# Altium schematic sheet BlazeBus_1.SchDoc
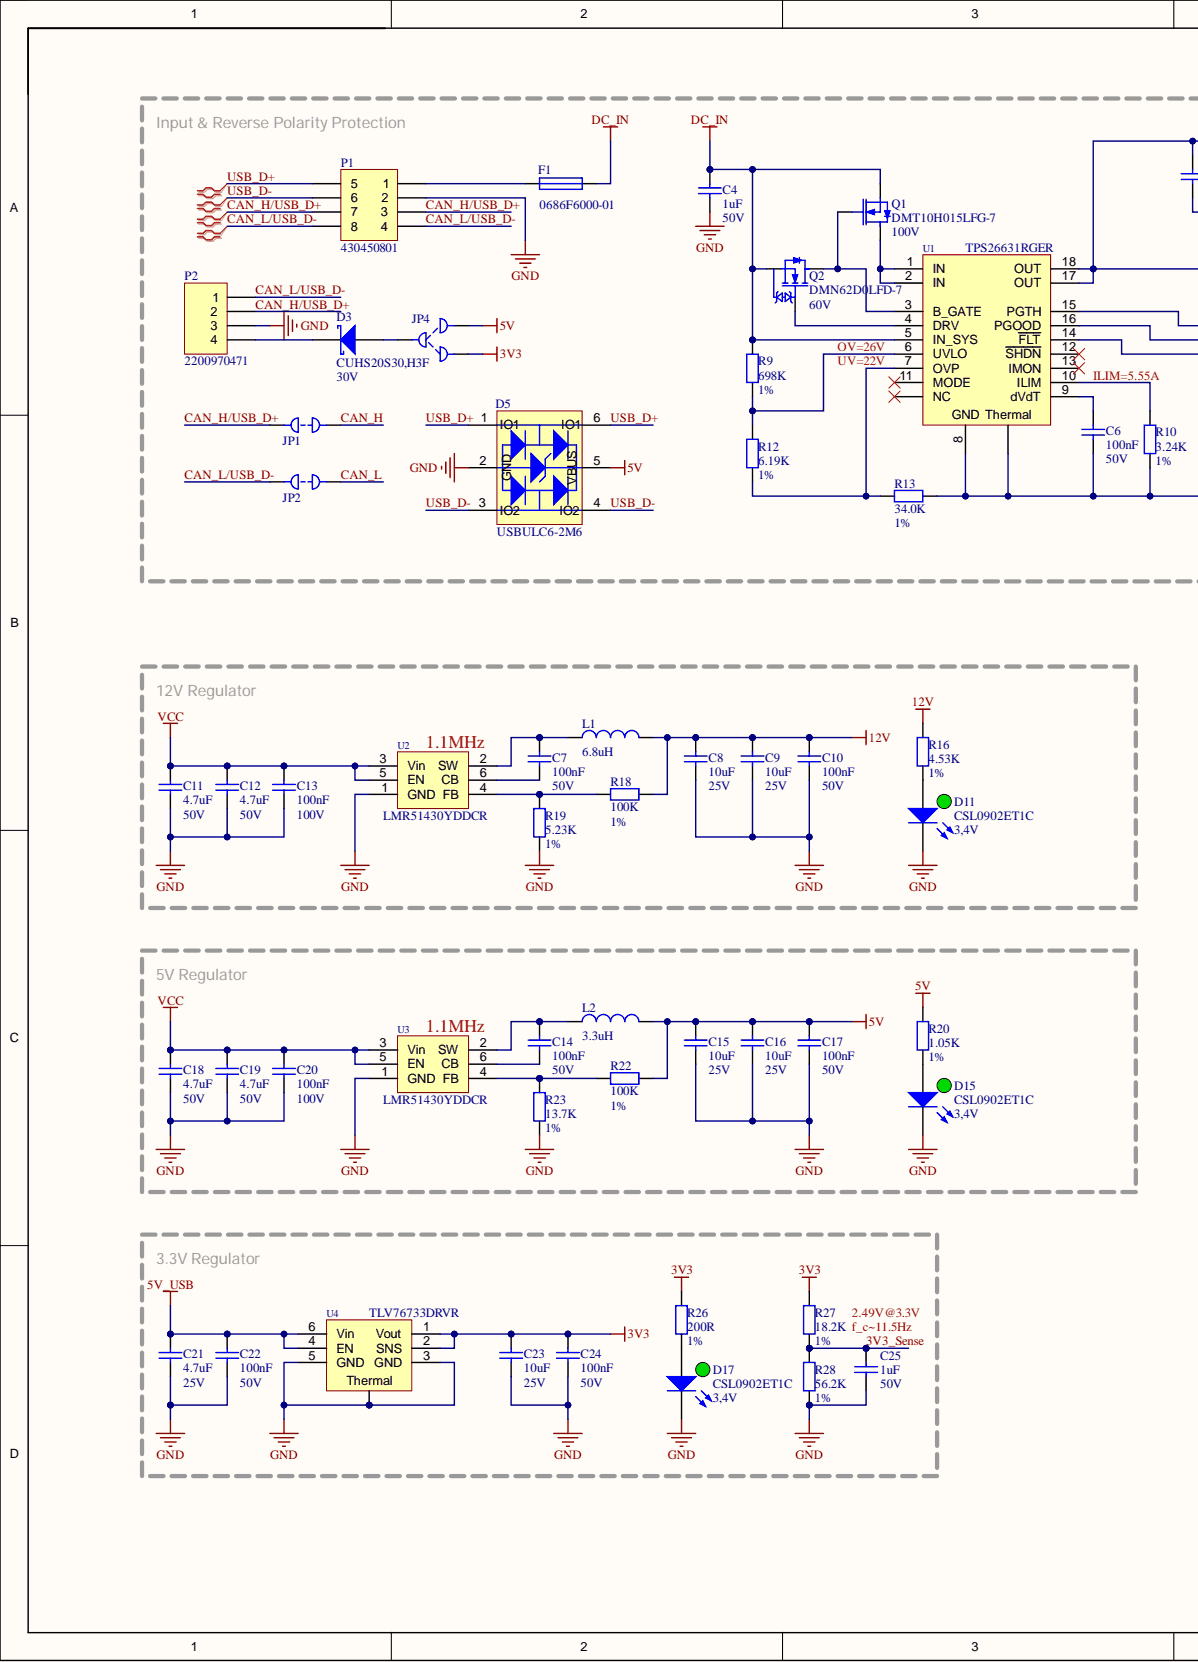
[diagram p0: BlazeBus_1.SchDoc, left]
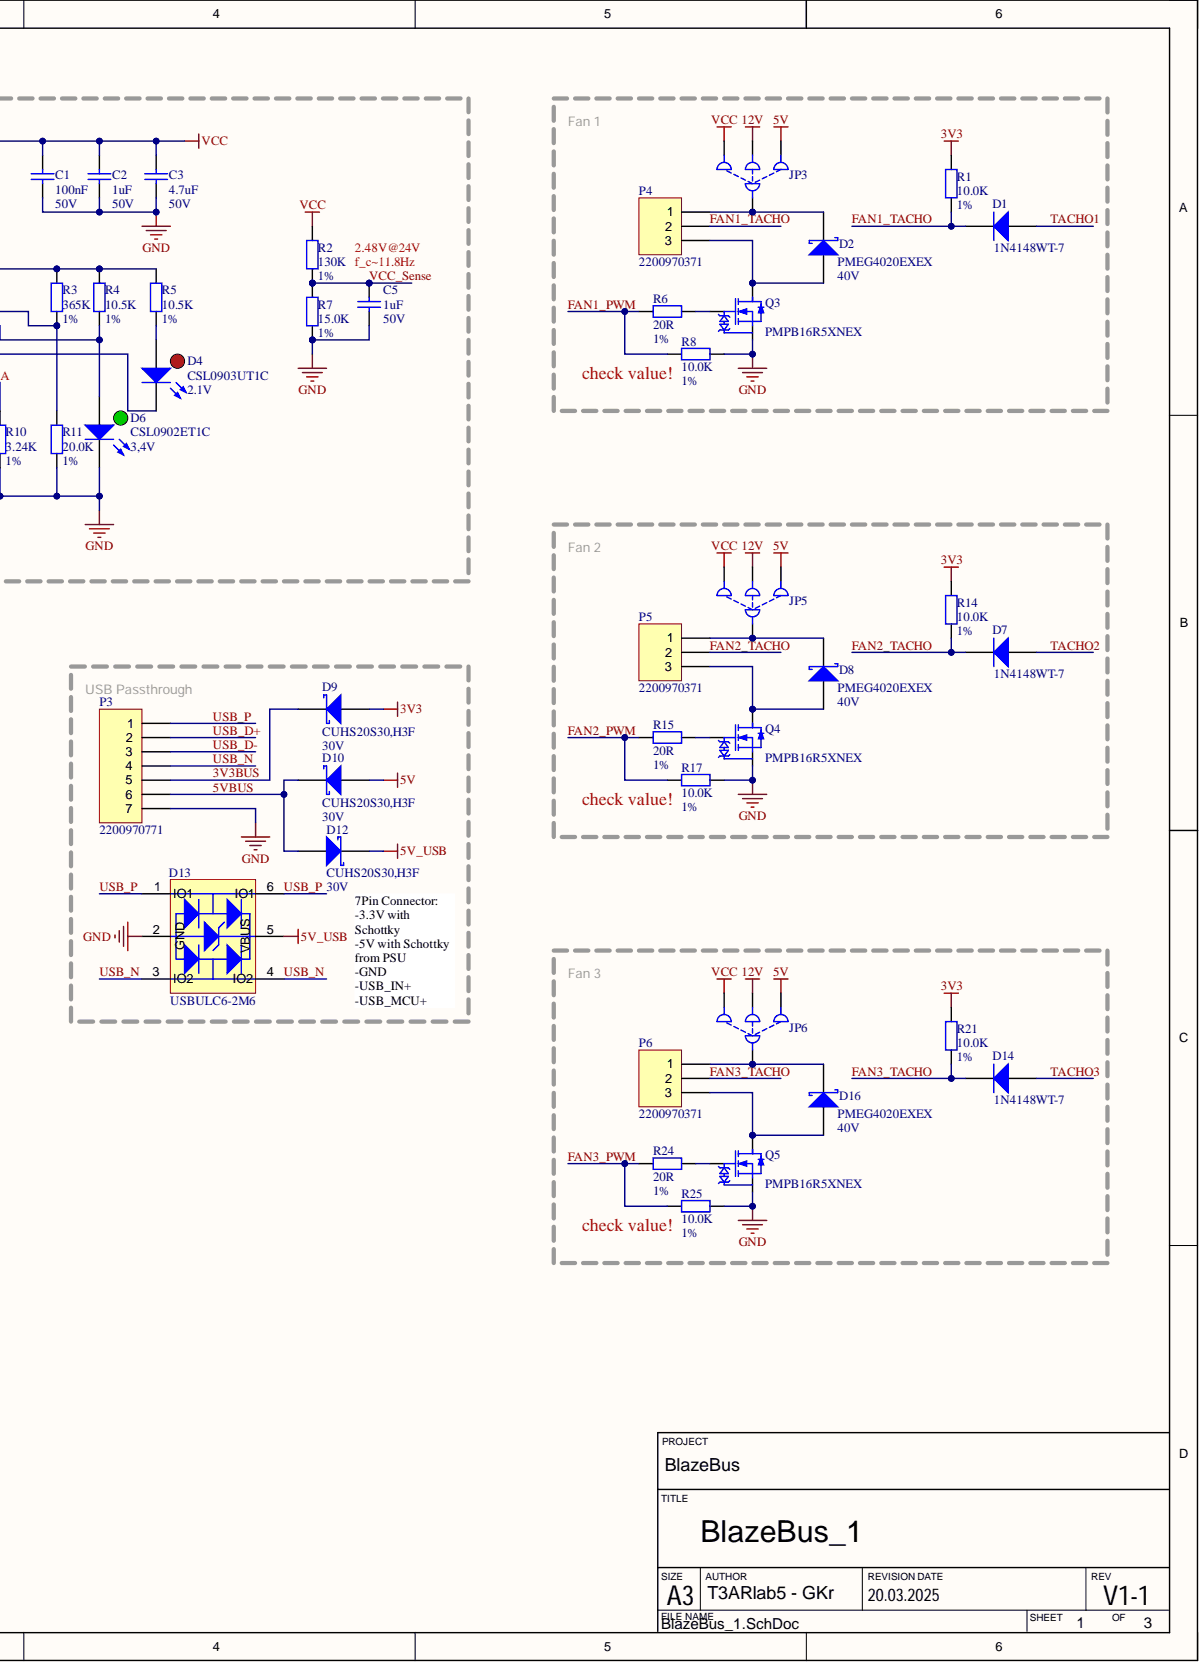
[diagram p1: BlazeBus_1.SchDoc, right]

## Parts
C1  C  value 100nF  Voltage Rating: 50V  [C_0402]
C2  C  value 1uF  Voltage Rating: 50V  [C_0603]
C3  C  value 4.7uF  Voltage Rating: 50V  [C_1206]
C4  C  value 1uF  Voltage Rating: 50V  [C_0805]
C5  C  value 1uF  Voltage Rating: 50V  [C_0603]
C6  C  value 100nF  Voltage Rating: 50V  [C_0402]
C7  C  value 100nF  Voltage Rating: 50V  [C_0402]
C8  C  value 10uF  Voltage Rating: 25V  [C_1206]
C9  C  value 10uF  Voltage Rating: 25V  [C_1206]
C10  C  value 100nF  Voltage Rating: 50V  [C_0402]
C11  C  value 4.7uF  Voltage Rating: 50V  [C_1206]
C12  C  value 4.7uF  Voltage Rating: 50V  [C_1206]
C13  C  value 100nF  Voltage Rating: 100V  [C_0603]
C14  C  value 100nF  Voltage Rating: 50V  [C_0402]
C15  C  value 10uF  Voltage Rating: 25V  [C_1206]
C16  C  value 10uF  Voltage Rating: 25V  [C_1206]
C17  C  value 100nF  Voltage Rating: 50V  [C_0402]
C18  C  value 4.7uF  Voltage Rating: 50V  [C_1206]
C19  C  value 4.7uF  Voltage Rating: 50V  [C_1206]
C20  C  value 100nF  Voltage Rating: 100V  [C_0603]
C21  C  value 4.7uF  Voltage Rating: 25V  [C_0805]
C22  C  value 100nF  Voltage Rating: 50V  [C_0402]
C23  C  value 10uF  Voltage Rating: 25V  [C_1206]
C24  C  value 100nF  Voltage Rating: 50V  [C_0402]
C25  C  value 1uF  Voltage Rating: 50V  [C_0603]
D1  Manufacturer ID: 1N4148WT-7  [SOD523]  (hidden comment: D_A2K1)
D2  Manufacturer ID: PMEG4020EXEX  Voltage Rating: 40V  [SOD123HP]  (hidden comment: D_Schottky_A2K1)
D3  Manufacturer ID: CUHS20S30,H3F  Voltage Rating: 30V  [D_2-SMD]  (hidden comment: D_Schottky_A2K1)
D4  Manufacturer ID: CSL0903UT1C  Voltage Rating: 2.1V  [LED_0603_red_Rohm]  (hidden comment: LED_red)
D5  Manufacturer ID: USBULC6-2M6  [6-UFDFN]  (hidden comment: D_TVS_USB)
D6  Manufacturer ID: CSL0902ET1C  Voltage Rating: 3,4V  [LED_0603_green_Rohm]  (hidden comment: LED_green)
D7  Manufacturer ID: 1N4148WT-7  [SOD523]  (hidden comment: D_A2K1)
D8  Manufacturer ID: PMEG4020EXEX  Voltage Rating: 40V  [SOD123HP]  (hidden comment: D_Schottky_A2K1)
D9  Manufacturer ID: CUHS20S30,H3F  [D_2-SMD]  (hidden comment: D_Schottky_A2K1)
D10  Manufacturer ID: CUHS20S30,H3F  Voltage Rating: 30V  [D_2-SMD]  (hidden comment: D_Schottky_A2K1)
D11  Manufacturer ID: CSL0902ET1C  Voltage Rating: 3,4V  [LED_0603_green_Rohm]  (hidden comment: LED_green)
D12  Manufacturer ID: CUHS20S30,H3F  Voltage Rating: 30V  [D_2-SMD]  (hidden comment: D_Schottky_A2K1)
D13  Manufacturer ID: USBULC6-2M6  [6-UFDFN]  (hidden comment: D_TVS_USB)
D14  Manufacturer ID: 1N4148WT-7  [SOD523]  (hidden comment: D_A2K1)
D15  Manufacturer ID: CSL0902ET1C  Voltage Rating: 3,4V  [LED_0603_green_Rohm]  (hidden comment: LED_green)
D16  Manufacturer ID: PMEG4020EXEX  Voltage Rating: 40V  [SOD123HP]  (hidden comment: D_Schottky_A2K1)
D17  Manufacturer ID: CSL0902ET1C  Voltage Rating: 3,4V  [LED_0603_green_Rohm]  (hidden comment: LED_green)
F1  Manufacturer ID: 0686F6000-01  [F_0603]  (hidden comment: Fuse)
JP1  Jumper  [R_0402 Jumper]  (value not drawn)
JP2  Jumper  [R_0402 Jumper]  (value not drawn)
JP3  Jumper 3-Way  [R_0402 Jumper 3-Way]  (value not drawn)
JP4  Jumper 2-Way  [R_0402 Jumper 2-Way]  (value not drawn)
JP5  Jumper 3-Way  [R_0402 Jumper 3-Way]  (value not drawn)
JP6  Jumper 3-Way  [R_0402 Jumper 3-Way]  (value not drawn)
L1  L  value 6.8uH  [MAPI-5030]
L2  L  value 3.3uH  [MAPI-4020]
P1  Manufacturer ID: 430450801  [MicroFit3.0-8-DR-RA]  (hidden comment: MicroFit 3.0-8)
P2  Manufacturer ID: 2200970471  [Micro-Lock_Plus-4]  (hidden comment: Header 4)
P3  Manufacturer ID: 2200970771  [Micro-Lock_Plus-7]  (hidden comment: Header 7)
P4  Manufacturer ID: 2200970371  [Micro-Lock_Plus-3]  (hidden comment: Header 3)
P5  Manufacturer ID: 2200970371  [Micro-Lock_Plus-3]  (hidden comment: Header 3)
P6  Manufacturer ID: 2200970371  [Micro-Lock_Plus-3]  (hidden comment: Header 3)
Q1  Manufacturer ID: DMT10H015LFG-7  Voltage Rating: 100V  [PowerDI3333-8]  (hidden comment: MOSFET-N-Depletion-SSSGDDDD)
Q2  Manufacturer ID: DMN62D0LFD-7  Voltage Rating: 60V  [DFN1212-3]  (hidden comment: MOSFET-N_GDS)
Q3  Manufacturer ID: PMPB16R5XNEX  [DFN2020M-6]  (hidden comment: MOSFET-N_GDS)
Q4  Manufacturer ID: PMPB16R5XNEX  [DFN2020M-6]  (hidden comment: MOSFET-N_GDS)
Q5  Manufacturer ID: PMPB16R5XNEX  [DFN2020M-6]  (hidden comment: MOSFET-N_GDS)
R1  R  value 10.0K  Tolerance: 1%  [R_0402]
R2  R  value 130K  Tolerance: 1%  [R_0402]
R3  R  value 365K  Tolerance: 1%  [R_0402]
R4  R  value 10.5K  Tolerance: 1%  [R_0402]
R5  R  value 10.5K  Tolerance: 1%  [R_0402]
R6  R  value 20R  [R_0402]
R7  R  value 15.0K  Tolerance: 1%  [R_0402]
R8  R  value 10.0K  Tolerance: 1%  [R_0402]
R9  R  value 698K  Tolerance: 1%  [R_0402]
R10  R  value 3.24K  Tolerance: 1%  [R_0402]
R11  R  value 20.0K  Tolerance: 1%  [R_0402]
R12  R  value 6.19K  Tolerance: 1%  [R_0402]
R13  R  value 34.0K  Tolerance: 1%  [R_0402]
R14  R  value 10.0K  Tolerance: 1%  [R_0402]
R15  R  value 20R  [R_0402]
R16  R  value 4.53K  Tolerance: 1%  [R_0402]
R17  R  value 10.0K  Tolerance: 1%  [R_0402]
R18  R  value 100K  Tolerance: 1%  [R_0402]
R19  R  value 5.23K  Tolerance: 1%  [R_0402]
R20  R  value 1.05K  Tolerance: 1%  [R_0402]
R21  R  value 10.0K  Tolerance: 1%  [R_0402]
R22  R  value 100K  Tolerance: 1%  [R_0402]
R23  R  value 13.7K  Tolerance: 1%  [R_0402]
R24  R  value 20R  [R_0402]
R25  R  value 10.0K  Tolerance: 1%  [R_0402]
R26  R  value 200R  Tolerance: 1%  [R_0402]
R27  R  value 18.2K  Tolerance: 1%  [R_0402]
R28  R  value 56.2K  Tolerance: 1%  [R_0402]
U1  TPS26631  Manufacturer ID: TPS26631RGER  [VQFN-24]
U2  LMR51430  Manufacturer ID: LMR51430YDDCR  [SOT-23-6]
U3  LMR51430  Manufacturer ID: LMR51430YDDCR  [SOT-23-6]
U4  TLV76733  Manufacturer ID: TLV76733DRVR  [WSON-6]

## Nets
3V3: C23.2 C24.2 D9.2 JP4.3 R1.2 R14.2 R21.2 R26.2 R27.2 U4.1(Vout) U4.2(SNS)
5V: C15.2 C16.2 C17.2 D5.5 D10.2 JP3.3 JP4.1 JP5.3 JP6.3 L2.2 R20.2 R22.1
5V_USB: C21.2 C22.2 D12.1 D13.5 U4.4(EN) U4.6(Vin)
12V: C8.2 C9.2 C10.2 JP3.2 JP5.2 JP6.2 L1.2 R16.2 R18.1
DC_IN: C4.2 F1.2 Q1.1,2,3 Q2.2 R9.1 U1.5(IN_SYS)
GND: C1.1 C2.1 C3.1 C4.1 C5.1 C6.1 C8.1 C9.1 C10.1 C11.1 C12.1 C13.1 C15.1 C16.1 C17.1 C18.1 C19.1 C20.1 C21.1 C22.1 C23.1 C24.1 C25.1 D5.2 D6.K D11.K D13.2 D15.K D17.K P1.2 P2.3 P3.7 Q3.4,8 Q4.4,8 Q5.4,8 R7.1 R8.2 R10.1 R11.1 R13.2 R17.2 R19.1 R23.1 R25.2 R28.1 U1.8 U1.Thermal U2.1(GND) U3.1(GND) U4.3(GND) U4.5(GND) U4.Thermal
VCC: C1.2 C2.2 C3.2 C11.2 C12.2 C13.2 C18.2 C19.2 C20.2 JP3.1 JP5.1 JP6.1 R2.2 R3.1 R4.2 R5.2 U1.17(OUT) U1.18(OUT) U2.3(Vin) U2.5(EN) U3.3(Vin) U3.5(EN)
3V3_Sense: C25.2 R27.1 R28.2
3V3BUS: D9.1 P3.5
5VBUS: D10.1 D12.2 P3.6
CAN_H: JP1.2
CAN_H/USB_D+: JP1.1 P1.3 P1.7 P2.2
CAN_L: JP2.2
CAN_L/USB_D-: JP2.1 P1.4 P1.8 P2.1
FAN1_PWM: R6.1 R8.1
FAN1_TACHO: D1.1 P4.2 R1.1
FAN2_PWM: R15.1 R17.1
FAN2_TACHO: D7.1 P5.2 R14.1
FAN3_PWM: R24.1 R25.1
FAN3_TACHO: D14.1 P6.2 R21.1
TACHO1: D1.2
TACHO2: D7.2
TACHO3: D14.2
USB_D+: D5.1(IO1) D5.6(IO1) P1.5 P3.2
USB_D-: D5.3(IO2) D5.4(IO2) P1.6 P3.3
USB_N: D13.3(IO2) D13.4(IO2) P3.4
USB_P: D13.1(IO1) D13.6(IO1) P3.1
VCC_Sense: C5.2 R2.1 R7.2
NetC6_2: C6.2 U1.9(dVdT)
NetC7_1: C7.1 U2.6(CB)
NetC7_2: C7.2 L1.1 U2.2(SW)
NetC14_1: C14.1 U3.6(CB)
NetC14_2: C14.2 L2.1 U3.2(SW)
NetD2_1: D2.1 JP3.4 P4.1
NetD2_2: D2.2 P4.3 Q3.1,2,5,6,7
NetD3_1: D3.1 P2.4
NetD3_2: D3.2 JP4.2
NetD4_A: D4.A R5.1
NetD4_K: D4.K U1.14(FLT)
NetD6_A: D6.A R4.1 U1.16(PGOOD)
NetD8_1: D8.1 JP5.4 P5.1
NetD8_2: D8.2 P5.3 Q4.1,2,5,6,7
NetD11_A: D11.A R16.1
NetD15_A: D15.A R20.1
NetD16_1: D16.1 JP6.4 P6.1
NetD16_2: D16.2 P6.3 Q5.1,2,5,6,7
NetD17_A: D17.A R26.1
NetF1_1: F1.1 P1.1
NetQ1_4: Q1.4 Q2.3 U1.3(B_GATE)
NetQ1_5,6,7,8: Q1.5,6,7,8 U1.1(IN) U1.2(IN)
NetQ2_1: Q2.1 U1.4(DRV)
NetQ3_3: Q3.3 R6.2
NetQ4_3: Q4.3 R15.2
NetQ5_3: Q5.3 R24.2
NetR3_2: R3.2 R11.2 U1.15(PGTH)
NetR9_2: R9.2 R12.1 U1.6(UVLO)
NetR10_2: R10.2 U1.10(ILIM)
NetR12_2: R12.2 R13.1 U1.7(OVP)
NetR18_2: R18.2 R19.2 U2.4(FB)
NetR22_2: R22.2 R23.2 U3.4(FB)

Not connected: U1 11(MODE) 12(SHDN) 13(IMON) 19,20,21,22,23,24(NC)

## Library data of the parts
C1 C6 C7 C10 C14 C17 C22 C24 = 02_0122: Capacitor 100nF 50V ±10% 0402 Ceramic SMD; Manufacturer: Yageo; Database Table Name: 02_Capacitors$; Temperature Coefficient: X7R; Component Kind: Standard; Library Name: Library_DBLib.DbLib; Mounting Style: SMD; Part ID: 02_0122; Temperature Range: -55°C to +125°C; Tolerance: ±10%; Type: Ceramic
C2 C5 C25 = 02_0270: Capacitor 1uF 50V ±10% 0603 Ceramic SMD; Manufacturer: Yageo; Database Table Name: 02_Capacitors$; Temperature Coefficient: X7R; Component Kind: Standard; Library Name: Library_DBLib.DbLib; Mounting Style: SMD; Part ID: 02_0270; Temperature Range: -55°C to +125°C; Tolerance: ±10%; Type: Ceramic
C3 C11 C12 C18 C19 = 02_0576: Capacitor 4.7uF 50V ±10% 1206 Ceramic SMD; Manufacturer: Yageo; Database Table Name: 02_Capacitors$; Temperature Coefficient: X7R; Component Kind: Standard; Library Name: Library_DBLib.DbLib; Mounting Style: SMD; Part ID: 02_0576; Temperature Range: -55°C to +125°C; Tolerance: ±10%; Type: Ceramic
C4 = 02_0424: Capacitor 1uF 50V ±10% 0805 Ceramic SMD; Manufacturer: Yageo; Database Table Name: 02_Capacitors$; Temperature Coefficient: X7R; Component Kind: Standard; Library Name: Library_DBLib.DbLib; Mounting Style: SMD; Part ID: 02_0424; Temperature Range: -55°C to +125°C; Tolerance: ±10%; Type: Ceramic
C8 C9 C15 C16 C23 = 02_0532: Capacitor 10uF 25V ±10% 1206 Ceramic SMD; Manufacturer: Yageo; Database Table Name: 02_Capacitors$; Temperature Coefficient: X7R; Component Kind: Standard; Library Name: Library_DBLib.DbLib; Mounting Style: SMD; Part ID: 02_0532; Temperature Range: -55°C to +125°C; Tolerance: ±10%; Type: Ceramic
C13 C20 = 02_0297: Capacitor 100nF 100V ±10% 0603 Ceramic SMD; Manufacturer: Yageo; Database Table Name: 02_Capacitors$; Temperature Coefficient: X7R; Component Kind: Standard; Library Name: Library_DBLib.DbLib; Mounting Style: SMD; Part ID: 02_0297; Temperature Range: -55°C to +125°C; Tolerance: ±10%; Type: Ceramic
C21 = 02_0375: Capacitor 4.7uF 25V ±10% 0805 Ceramic SMD; Manufacturer: Yageo; Database Table Name: 02_Capacitors$; Temperature Coefficient: X7R; Component Kind: Standard; Library Name: Library_DBLib.DbLib; Mounting Style: SMD; Part ID: 02_0375; Temperature Range: -55°C to +125°C; Tolerance: ±10%; Type: Ceramic
D1 D7 D14 = 04_0009: PN Diode 75V 125mA; Manufacturer: Diodes Inc.; Code - JEDEC: DO-214-AB; Current Rating: 125mA, 2A Peak; Database Table Name: 04_Diodes$; Component Kind: Standard; Digikey ID: 1N4148WTDICT-ND; LCSC ID: C83482; Library Name: Library_DBLib.DbLib; Mouser ID: 621-1N4148WT; Part ID: 04_0009; Published: 8-Jun-2000; Revision: July-2002: Re-released for DXP Platform.; Signal Integrity: Diode; Simulation: DIODE; Temperature Range: -65°C to +150°C; Type: PN; Voltage Rating: 75V; Publisher: Altium Limited
D2 D8 D16 = 04_0006: Schottky 40V 2A 0.57Vf; Manufacturer: Nexperia; Code - JEDEC: DO-214-AA; Current Rating: 2A, 50A Pulse; Database Table Name: 04_Diodes$; Component Kind: Standard; Digikey ID: 1727-PMEG4020EXEXCT-ND; Library Name: Library_DBLib.DbLib; Mouser ID: 771-PMEG4020EXEX; Part ID: 04_0006; Published: 8-Jun-2000; Revision: July-2002: Re-released for DXP Platform.; Signal Integrity: D Schottky; Simulation: SKYDIODE; Temperature Range: -55°C to +175°C; Type: Schottky; Publisher: Altium Limited
D3 D9 D10 D12 = 04_0005: Schottky 30V 2A 0.41Vf; Manufacturer: Toshiba; Code - JEDEC: DO-214-AA; Current Rating: 2A, 10A Peak; Database Table Name: 04_Diodes$; Component Kind: Standard; Digikey ID: CUHS20S30H3FCT-ND; LCSC ID: C5636538; Library Name: Library_DBLib.DbLib; Mouser ID: 757-CUHS20S30H3F; Part ID: 04_0005; Published: 8-Jun-2000; Revision: July-2002: Re-released for DXP Platform.; Signal Integrity: D Schottky; Simulation: SKYDIODE; Temperature Range: -55°C to +150°C; Type: Schottky; Publisher: Altium Limited
  D9: Voltage Rating: 30V
D4 = 04_0003: LED SMD Red 1.2cd@20mA 0603; Manufacturer: Rohm; Current Rating: 35mA; Database Table Name: 04_Diodes$; Power Rating: 87mW; Type: LED Red; Component Kind: Standard; Digikey ID: 846-CSL0903UT1CCT-ND; Library Name: Library_DBLib.DbLib; Mouser ID: 755-CSL0903UT1C; Part ID: 04_0003; Temperature Range: -40°C to +110°C
D5 D13 = 04_0008: TVS ESD USB; Manufacturer: STMicroelectronics; Database Table Name: 04_Diodes$; Component Kind: Standard; Digikey ID: 497-7044-1-ND; LCSC ID: C672213; Library Name: Library_DBLib.DbLib; Mouser ID: 511-USBULC6-2M6; Part ID: 04_0008; Revision: July-2002: Re-released for DXP Platform.; Signal Integrity: D Schottky; Temperature Range: -55°C to +150°C; Type: TVS
D6 D11 D15 D17 = 04_0004: LED SMD Green 1.2cd@20mA 0603; Manufacturer: Rohm; Current Rating: 30mA; Database Table Name: 04_Diodes$; Power Rating: 114mW; Type: LED Green; Component Kind: Standard; Digikey ID: 846-CSL0902ET1CCT-ND; LCSC ID: C5603524; Library Name: Library_DBLib.DbLib; Mouser ID: 755-CSL0902ET1C; Part ID: 04_0004; Temperature Range: -40°C to +110°C
F1 = 08_0008: Fuse 63V 6A Fast-acting; Manufacturer: Bel Fuse; Database Table Name: 08_Misc$; Component Kind: Standard; Current Rating: 6A; Digikey ID: 5923-0686F6000-01CT-ND; LCSC ID: C3166181; Library Name: Library_DBLib.DbLib; Mouser ID: 530-0686F6000-01; Part ID: 08_0008; Published: 8-Jun-2000; Revision: July-2002: Re-released for DXP Platform.; Simulation: FUSE; Temperature Range: -55°C to +125°C; Type: Fuse; Voltage Rating: 63V; Publisher: Altium Limited
JP1 JP2 = 07_0026: 0402 Jumper, solderable; Database Table Name: 07_Connectors$; Component Kind: Standard; Library Name: Library_DBLib.DbLib; Part ID: 07_0026; Type: 0402 Jumper, solderable
JP3 JP5 JP6 = 07_0017: 0402 Jumper, 3-Way; Database Table Name: 07_Connectors$; Component Kind: Standard; Library Name: Library_DBLib.DbLib; Part ID: 07_0017; Type: 0402 Jumper, 3-Way
JP4 = 07_0030: 0402 Jumper, 2-Way; Database Table Name: 07_Connectors$; Component Kind: Standard; Library Name: Library_DBLib.DbLib; Part ID: 07_0030; Type: 0402 Jumper, 2-Way
L1 = 03_0003: Shielded Inductor, 6.8uH, 20%, 4.3A, 5.7A Isat; Manufacturer: Würth; Manufacturer ID: 74438367068; Database Table Name: 03_Inductors$; Component Kind: Standard; Current Rating: 4.3A, 5.7A Isat; Digikey ID: 732-74438367068CT-ND; Library Name: Library_DBLib.DbLib; Mouser ID: 710-74438367068; Part ID: 03_0003; Signal Integrity: Inductor; Simulation: INDUCTOR; Temperature Range: -40°C to +125°C; Tolerance: ±20%
L2 = 03_0002: Shielded Inductor, 3.3uH, 20%, 5.15A, 7.1A Isat; Manufacturer: Würth; Manufacturer ID: 74438356033; Database Table Name: 03_Inductors$; Component Kind: Standard; Current Rating: 5.15A, 7.1A Isat; Digikey ID: 732-8233-1-ND; Library Name: Library_DBLib.DbLib; Mouser ID: 710-74438356033; Part ID: 03_0002; Signal Integrity: Inductor; Simulation: INDUCTOR; Temperature Range: -40°C to +125°C; Tolerance: ±20%
P1 = 07_0027: Micro-Fit, 8Pin, RA, THT, 3.00mm Pitch, 0.38µ Gold; Manufacturer: Molex; Current Rating: 8.5A; Database Table Name: 07_Connectors$; Voltage Rating: 600V; Component Kind: Standard; Digikey ID: WM3253-ND; LCSC ID: C5765943; Library Name: Library_DBLib.DbLib; Mounting Style: THT; Mouser ID: 538-43045-0801; Orientation: Horizontal; Part ID: 07_0027; Pitch: 3.00mm; Revision: 17-Jul-2002: Re-released for DXP Platform.; Temperature Range: -40°C to +125°C; Type: 8Pin Micro-Fit Header; Publisher: Altium Limited
P2 = 07_0024: Micro-Lock Plus, 4Pin, THT, 1.50mm Pitch, Tin-Bismuth; Manufacturer: Molex; Current Rating: 3,3A; Database Table Name: 07_Connectors$; Voltage Rating: 50V; Component Kind: Standard; Digikey ID: 900-2200970471-ND; Library Name: Library_DBLib.DbLib; Mounting Style: THT; Mouser ID: 538-220097-0471; Orientation: Vertical; Part ID: 07_0024; Pitch: 1.50mm; Revision: 17-Jul-2002: Re-released for DXP Platform.; Signal Integrity: Connector; Temperature Range: -40°C to +105°C; Type: 4Pin Micro-Lock Plus Header; Publisher: Altium Limited
P3 = 07_0028: Micro-Lock Plus, 7Pin, THT, 1.50mm Pitch, Tin-Bismuth; Manufacturer: Molex; Current Rating: 3,3A; Database Table Name: 07_Connectors$; Voltage Rating: 50V; Component Kind: Standard; Digikey ID: 900-2200970771-ND; Library Name: Library_DBLib.DbLib; Mounting Style: THT; Mouser ID: 538-220097-0771; Orientation: Vertical; Part ID: 07_0028; Pitch: 1.50mm; Revision: 17-Jul-2002: Re-released for DXP Platform.; Signal Integrity: Connector; Temperature Range: -40°C to +105°C; Type: 7Pin Micro-Lock Plus Header; Publisher: Altium Limited
P4-P6 = 07_0023: Micro-Lock Plus, 3Pin, THT, 1.50mm Pitch, Tin-Bismuth; Manufacturer: Molex; Current Rating: 3,3A; Database Table Name: 07_Connectors$; Voltage Rating: 50V; Component Kind: Standard; Library Name: Library_DBLib.DbLib; Mounting Style: THT; Mouser ID: 538-220097-0371; Orientation: Vertical; Part ID: 07_0023; Pitch: 1.50mm; Revision: 17-Jul-2002: Re-released for DXP Platform.; Signal Integrity: Connector; Temperature Range: -40°C to +105°C; Type: 3Pin Micro-Lock Plus Header; Publisher: Altium Limited
Q1 = 05_0005: N-Channel 100V 10A 2W; Manufacturer: Diodes Inc.; Database Table Name: 05_Transistors$; Type: N-Mosfet; Component Kind: Standard; Current Rating: 10A; Digikey ID: DMT10H015LFG-7DICT-ND; LCSC ID: C5245407; Library Name: Library_DBLib.DbLib; Mouser ID: 621-DMT10H015LFG-7; Part ID: 05_0005; Power Rating: 2W; Revision: July-2002: Re-released for DXP Platform.; Temperature Range: -55°C to +150°C
Q2 = 05_0004: N-Channel 60V 310mA 480mW; Manufacturer: Diodes Inc.; Database Table Name: 05_Transistors$; Type: N-Mosfet; Component Kind: Standard; Current Rating: 310mA; Digikey ID: DMN62D0LFD-7DICT-ND; LCSC ID: C155366; Library Name: Library_DBLib.DbLib; Mouser ID: 621-DMN62D0LFD-7; Part ID: 05_0004; Power Rating: 480mW; Revision: July-2002: Re-released for DXP Platform.; Simulation: NMOS; Temperature Range: -55°C to +150°C
Q3-Q5 = 05_0002: N-Channel Trench 30V 7A 1.7W; Manufacturer: Nexperia; Database Table Name: 05_Transistors$; Power Rating: 7.7W; Type: N-Mosfet; Component Kind: Standard; Current Rating: 7A; Digikey ID: 1727-PMPB16R5XNEXCT-ND; LCSC ID: C3277699; Library Name: Library_DBLib.DbLib; Mouser ID: 771-PMPB16R5XNEX; Part ID: 05_0002; Revision: July-2002: Re-released for DXP Platform.; Simulation: NMOS; Temperature Range: -55°C to +150°C; Voltage Rating: 30V
R1 R8 R14 R17 R21 R25 = 01_0386: Resistor 10.0K 0402 1/16W 1%; Manufacturer: Yageo; Manufacturer ID: RC0402FR-0710KL; Current Rating: 1A; Database Table Name: 01_Resistors$; Temperature Coefficient: ± 100ppm/℃; Voltage Rating: 50V; Component Kind: Standard; Library Name: Library_DBLib.DbLib; Mouser ID: 603-RC0402FR-0710KL; Part ID: 01_0386; Power Rating: 1/16W; Temperature Range: -55°C to +155°C
R2 = 01_0493: Resistor 130K 0402 1/16W 1%; Manufacturer: Yageo; Manufacturer ID: RC0402FR-07130KL; Current Rating: 1A; Database Table Name: 01_Resistors$; Temperature Coefficient: ± 100ppm/℃; Voltage Rating: 50V; Component Kind: Standard; Library Name: Library_DBLib.DbLib; Mouser ID: 603-RC0402FR-07130KL; Part ID: 01_0493; Power Rating: 1/16W; Temperature Range: -55°C to +155°C
R3 = 01_0536: Resistor 365K 0402 1/16W 1%; Manufacturer: Yageo; Manufacturer ID: RC0402FR-07365KL; Current Rating: 1A; Database Table Name: 01_Resistors$; Temperature Coefficient: ± 100ppm/℃; Voltage Rating: 50V; Component Kind: Standard; Library Name: Library_DBLib.DbLib; Mouser ID: 603-RC0402FR-07365KL; Part ID: 01_0536; Power Rating: 1/16W; Temperature Range: -55°C to +155°C
R4 R5 = 01_0388: Resistor 10.5K 0402 1/16W 1%; Manufacturer: Yageo; Manufacturer ID: RC0402FR-0710K5L; Current Rating: 1A; Database Table Name: 01_Resistors$; Temperature Coefficient: ± 100ppm/℃; Voltage Rating: 50V; Component Kind: Standard; Library Name: Library_DBLib.DbLib; Mouser ID: 603-RC0402FR-0710K5L; Part ID: 01_0388; Power Rating: 1/16W; Temperature Range: -55°C to +155°C
R6 R15 R24 = 01_0127: Resistor 20R 0402 1/16W 1%; Manufacturer: Yageo; Manufacturer ID: RC0402FR-0720RL; Current Rating: 1A; Database Table Name: 01_Resistors$; Temperature Coefficient: ± 100ppm/℃; Voltage Rating: 50V; Component Kind: Standard; Library Name: Library_DBLib.DbLib; Mouser ID: 603-RC0402FR-0720RL; Part ID: 01_0127; Power Rating: 1/16W; Temperature Range: -55°C to +155°C; Tolerance: 1%
R7 = 01_0403: Resistor 15.0K 0402 1/16W 1%; Manufacturer: Yageo; Manufacturer ID: RC0402FR-0715KL; Current Rating: 1A; Database Table Name: 01_Resistors$; Temperature Coefficient: ± 100ppm/℃; Voltage Rating: 50V; Component Kind: Standard; Library Name: Library_DBLib.DbLib; Mouser ID: 603-RC0402FR-0715KL; Part ID: 01_0403; Power Rating: 1/16W; Temperature Range: -55°C to +155°C
R9 = 01_0563: Resistor 698K 0402 1/16W 1%; Manufacturer: Yageo; Manufacturer ID: RC0402FR-07698KL; Current Rating: 1A; Database Table Name: 01_Resistors$; Temperature Coefficient: ± 100ppm/℃; Voltage Rating: 50V; Component Kind: Standard; Library Name: Library_DBLib.DbLib; Mouser ID: 603-RC0402FR-07698KL; Part ID: 01_0563; Power Rating: 1/16W; Temperature Range: -55°C to +155°C
R10 = 01_0339: Resistor 3.24K 0402 1/16W 1%; Manufacturer: Yageo; Manufacturer ID: RC0402FR-073K24L; Current Rating: 1A; Database Table Name: 01_Resistors$; Temperature Coefficient: ± 100ppm/℃; Voltage Rating: 50V; Component Kind: Standard; Library Name: Library_DBLib.DbLib; Mouser ID: 603-RC0402FR-073K24L; Part ID: 01_0339; Power Rating: 1/16W; Temperature Range: -55°C to +155°C
R11 = 01_0415: Resistor 20.0K 0402 1/16W 1%; Manufacturer: Yageo; Manufacturer ID: RC0402FR-0720KL; Current Rating: 1A; Database Table Name: 01_Resistors$; Temperature Coefficient: ± 100ppm/℃; Voltage Rating: 50V; Component Kind: Standard; Library Name: Library_DBLib.DbLib; Mouser ID: 603-RC0402FR-0720KL; Part ID: 01_0415; Power Rating: 1/16W; Temperature Range: -55°C to +155°C
R12 = 01_0366: Resistor 6.19K 0402 1/16W 1%; Manufacturer: Yageo; Manufacturer ID: RC0402FR-076K19L; Current Rating: 1A; Database Table Name: 01_Resistors$; Temperature Coefficient: ± 100ppm/℃; Voltage Rating: 50V; Component Kind: Standard; Library Name: Library_DBLib.DbLib; Mouser ID: 603-RC0402FR-076K19L; Part ID: 01_0366; Power Rating: 1/16W; Temperature Range: -55°C to +155°C
R13 = 01_0437: Resistor 34.0K 0402 1/16W 1%; Manufacturer: Yageo; Manufacturer ID: RC0402FR-0734KL; Current Rating: 1A; Database Table Name: 01_Resistors$; Temperature Coefficient: ± 100ppm/℃; Voltage Rating: 50V; Component Kind: Standard; Library Name: Library_DBLib.DbLib; Mouser ID: 603-RC0402FR-0734KL; Part ID: 01_0437; Power Rating: 1/16W; Temperature Range: -55°C to +155°C
R16 = 01_0353: Resistor 4.53K 0402 1/16W 1%; Manufacturer: Yageo; Manufacturer ID: RC0402FR-074K53L; Current Rating: 1A; Database Table Name: 01_Resistors$; Temperature Coefficient: ± 100ppm/℃; Voltage Rating: 50V; Component Kind: Standard; Library Name: Library_DBLib.DbLib; Mouser ID: 603-RC0402FR-074K53L; Part ID: 01_0353; Power Rating: 1/16W; Temperature Range: -55°C to +155°C
R18 R22 = 01_0482: Resistor 100K 0402 1/16W 1%; Manufacturer: Yageo; Manufacturer ID: RC0402FR-07100KL; Current Rating: 1A; Database Table Name: 01_Resistors$; Temperature Coefficient: ± 100ppm/℃; Voltage Rating: 50V; Component Kind: Standard; Library Name: Library_DBLib.DbLib; Mouser ID: 603-RC0402FR-07100KL; Part ID: 01_0482; Power Rating: 1/16W; Temperature Range: -55°C to +155°C
R19 = 01_0359: Resistor 5.23K 0402 1/16W 1%; Manufacturer: Yageo; Manufacturer ID: RC0402FR-075K23L; Current Rating: 1A; Database Table Name: 01_Resistors$; Temperature Coefficient: ± 100ppm/℃; Voltage Rating: 50V; Component Kind: Standard; Library Name: Library_DBLib.DbLib; Mouser ID: 603-RC0402FR-075K23L; Part ID: 01_0359; Power Rating: 1/16W; Temperature Range: -55°C to +155°C
R20 = 01_0292: Resistor 1.05K 0402 1/16W 1%; Manufacturer: Yageo; Manufacturer ID: RC0402FR-071K05L; Current Rating: 1A; Database Table Name: 01_Resistors$; Temperature Coefficient: ± 100ppm/℃; Voltage Rating: 50V; Component Kind: Standard; Library Name: Library_DBLib.DbLib; Mouser ID: 603-RC0402FR-071K05L; Part ID: 01_0292; Power Rating: 1/16W; Temperature Range: -55°C to +155°C
R23 = 01_0399: Resistor 13.7K 0402 1/16W 1%; Manufacturer: Yageo; Manufacturer ID: RC0402FR-0713K7L; Current Rating: 1A; Database Table Name: 01_Resistors$; Temperature Coefficient: ± 100ppm/℃; Voltage Rating: 50V; Component Kind: Standard; Library Name: Library_DBLib.DbLib; Mouser ID: 603-RC0402FR-0713K7L; Part ID: 01_0399; Power Rating: 1/16W; Temperature Range: -55°C to +155°C
R26 = 01_0223: Resistor 200R 0402 1/16W 1%; Manufacturer: Yageo; Manufacturer ID: RC0402FR-07200RL; Current Rating: 1A; Database Table Name: 01_Resistors$; Temperature Coefficient: ± 100ppm/℃; Voltage Rating: 50V; Component Kind: Standard; Library Name: Library_DBLib.DbLib; Mouser ID: 603-RC0402FR-07200RL; Part ID: 01_0223; Power Rating: 1/16W; Temperature Range: -55°C to +155°C
R27 = 01_0411: Resistor 18.2K 0402 1/16W 1%; Manufacturer: Yageo; Manufacturer ID: RC0402FR-0718K2L; Current Rating: 1A; Database Table Name: 01_Resistors$; Temperature Coefficient: ± 100ppm/℃; Voltage Rating: 50V; Component Kind: Standard; Library Name: Library_DBLib.DbLib; Mouser ID: 603-RC0402FR-0718K2L; Part ID: 01_0411; Power Rating: 1/16W; Temperature Range: -55°C to +155°C
R28 = 01_0458: Resistor 56.2K 0402 1/16W 1%; Manufacturer: Yageo; Manufacturer ID: RC0402FR-0756K2L; Current Rating: 1A; Database Table Name: 01_Resistors$; Temperature Coefficient: ± 100ppm/℃; Voltage Rating: 50V; Component Kind: Standard; Library Name: Library_DBLib.DbLib; Mouser ID: 603-RC0402FR-0756K2L; Part ID: 01_0458; Power Rating: 1/16W; Temperature Range: -55°C to +155°C
U1 = 06_0009: Hot Swap E-Fuse Controller, 4.5V to 60V, 31mΩ, 6A, VQFN-24; Manufacturer: Texas Instruments; Current Rating: 0.6A to 6A; Database Table Name: 06_ICs$; Component Kind: Standard; Digikey ID: 296-TPS26631RGERCT-ND; LCSC ID: C1850273; Library Name: Library_DBLib.DbLib; Mouser ID: 595-TPS26631RGER; Part ID: 06_0009; Temperature Range: -40°C to +150°C; Type: E-Fuse; Voltage Rating: 4.5V to 60V
U2 U3 = 06_0003: Synchronous Buck Converter, 4.5V to 36V, 3A, SOT-23; Manufacturer: Texas Instruments; Database Table Name: 06_ICs$; Component Kind: Standard; Current Rating: 3A; Digikey ID: 296-LMR51430YDDCRCT-ND; LCSC ID: C5210749; Library Name: Library_DBLib.DbLib; Mouser ID: 595-LMR51430YDDCR; Part ID: 06_0003; Temperature Range: -40°C to +150°C; Type: Buck Converter; Voltage Rating: 4.5V to 36V
U4 = 06_0004: LDO, 2.5V to 16V, 3.3V fixed, 1A, WSON-6; Manufacturer: Texas Instruments; Database Table Name: 06_ICs$; Component Kind: Standard; Current Rating: 1A; Digikey ID: 296-TLV76733DRVRCT-ND; LCSC ID: C2848334; Library Name: Library_DBLib.DbLib; Mouser ID: 595-TLV76733DRVR; Part ID: 06_0004; Temperature Range: -40°C to +125°C; Type: LDO; Voltage Rating: 2.5V to 16V
